# Altium schematic sheet ZYNQ_PWR_BANK.SchDoc
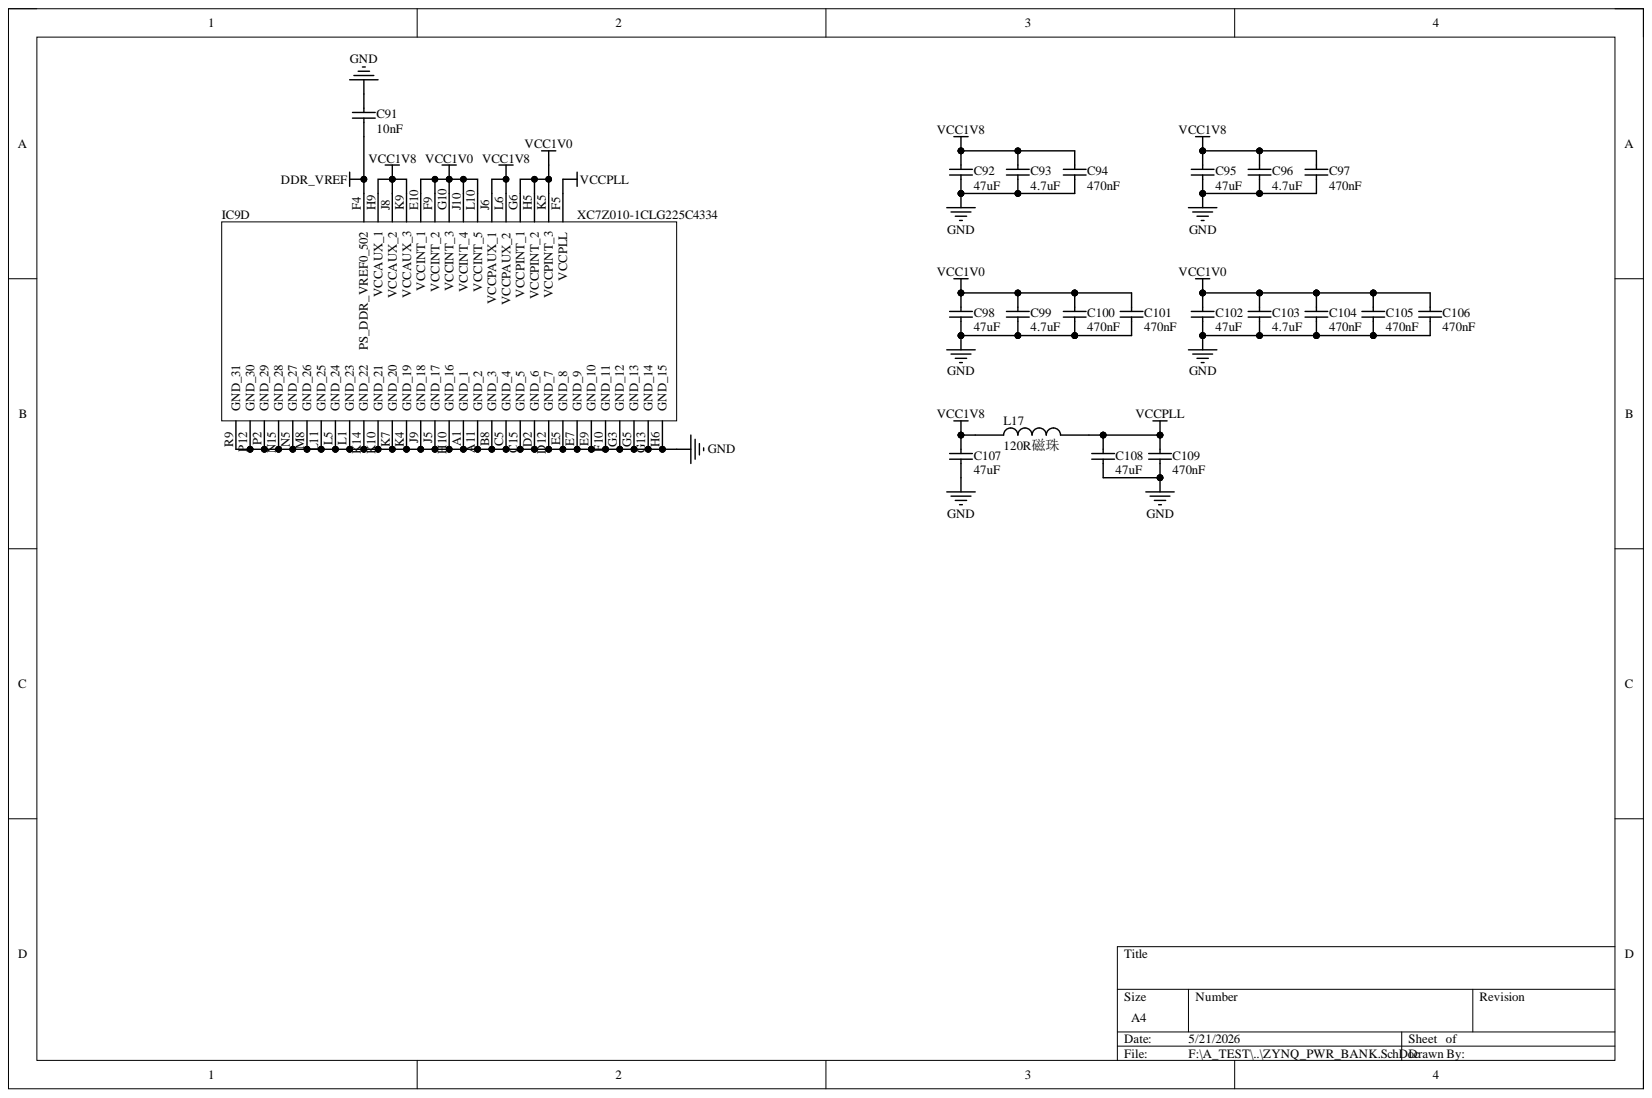
[diagram p0: ZYNQ_PWR_BANK.SchDoc]

## Parts
C91  10nF  [C 0402_L]
C92  47uF  [C 0805_L]
C93  4.7uF  [C 0603_L]
C94  470nF  [C 0402_L]
C95  47uF  [C 0805_L]
C96  4.7uF  [C 0603_L]
C97  470nF  [C 0402_L]
C98  47uF  [C 0805_L]
C99  4.7uF  [C 0603_L]
C100  470nF  [C 0402_L]
C101  470nF  [C 0402_L]
C102  47uF  [C 0805_L]
C103  4.7uF  [C 0603_L]
C104  470nF  [C 0402_L]
C105  470nF  [C 0402_L]
C106  470nF  [C 0402_L]
C107  47uF  [C 0805_L]
C108  47uF  [C 0805_L]
C109  470nF  [C 0402_L]
IC9  XC7Z010-1CLG225C4334  [BGA225C80P15X15_1300X1300X150]  (part D)
L17  120R磁珠  [L 0402]

## Nets
DDR_VREF: C91.1 IC9.F4(PS_DDR_VREF0_502)
GND: C91.2 C92.1 C93.1 C94.1 C95.1 C96.1 C97.1 C98.1 C99.1 C100.1 C101.1 C102.1 C103.1 C104.1 C105.1 C106.1 C107.1 C108.1 C109.1 IC9.A1(GND_1) IC9.A11(GND_2) IC9.B8(GND_3) IC9.C5(GND_4) IC9.C15(GND_5) IC9.D2(GND_6) IC9.D12(GND_7) IC9.E5(GND_8) IC9.E7(GND_9) IC9.E9(GND_10) IC9.F10(GND_11) IC9.G3(GND_12) IC9.G5(GND_13) IC9.G13(GND_14) IC9.H6(GND_15) IC9.H10(GND_16) IC9.J5(GND_17) IC9.J9(GND_18) IC9.K4(GND_19) IC9.K7(GND_20) IC9.K10(GND_21) IC9.K14(GND_22) IC9.L1(GND_23) IC9.L5(GND_24) IC9.L11(GND_25) IC9.M8(GND_26) IC9.N5(GND_27) IC9.N15(GND_28) IC9.P2(GND_29) IC9.P12(GND_30) IC9.R9(GND_31)
VCC1V0: C98.2 C99.2 C100.2 C101.2 C102.2 C103.2 C104.2 C105.2 C106.2 IC9.E10(VCCINT_1) IC9.F9(VCCINT_2) IC9.G6(VCCPINT_1) IC9.G10(VCCINT_3) IC9.H5(VCCPINT_2) IC9.J10(VCCINT_4) IC9.K5(VCCPINT_3) IC9.L10(VCCINT_5)
VCC1V8: C92.2 C93.2 C94.2 C95.2 C96.2 C97.2 C107.2 IC9.H9(VCCAUX_1) IC9.J6(VCCPAUX_1) IC9.J8(VCCAUX_2) IC9.K9(VCCAUX_3) IC9.L6(VCCPAUX_2) L17.1
VCCPLL: C108.2 C109.2 IC9.F5(VCCPLL) L17.2

## Library data of the parts
C91-C109 = Cap: 贴片电容; Component Kind: Standard; Library Name: A_Schlib.SchLib
  C91: value: 10nF
  C92 C95 C98 C102 C107 C108: value: 47uF
  C93 C96 C99 C103: value: 4.7uF
  C94 C97 C100 C101 C104-C106 C109: value: 470nF
IC9 = XC7Z010-1CLG225C4334: Integrated Circuit; Manufacturer_Name: AMD; Component Kind: Standard; Datasheet Link: https://docs.amd.com/api/khub/documents/kOAtMqkVamwUDaIfCXO91A/content?Ft-Calling-App=ft%2Fturnkey-portal&Ft-Calling-App-Version=5.2.5#; Height: 1.5mm; Library Name: SamacSys.SchLib; Manufacturer_Part_Number: XC7Z010-1CLG225C4334
L17 = 电感: 包含功率; Component Kind: Standard; Library Name: A_Schlib.SchLib
